# Altium schematic sheet OCB.SchDoc
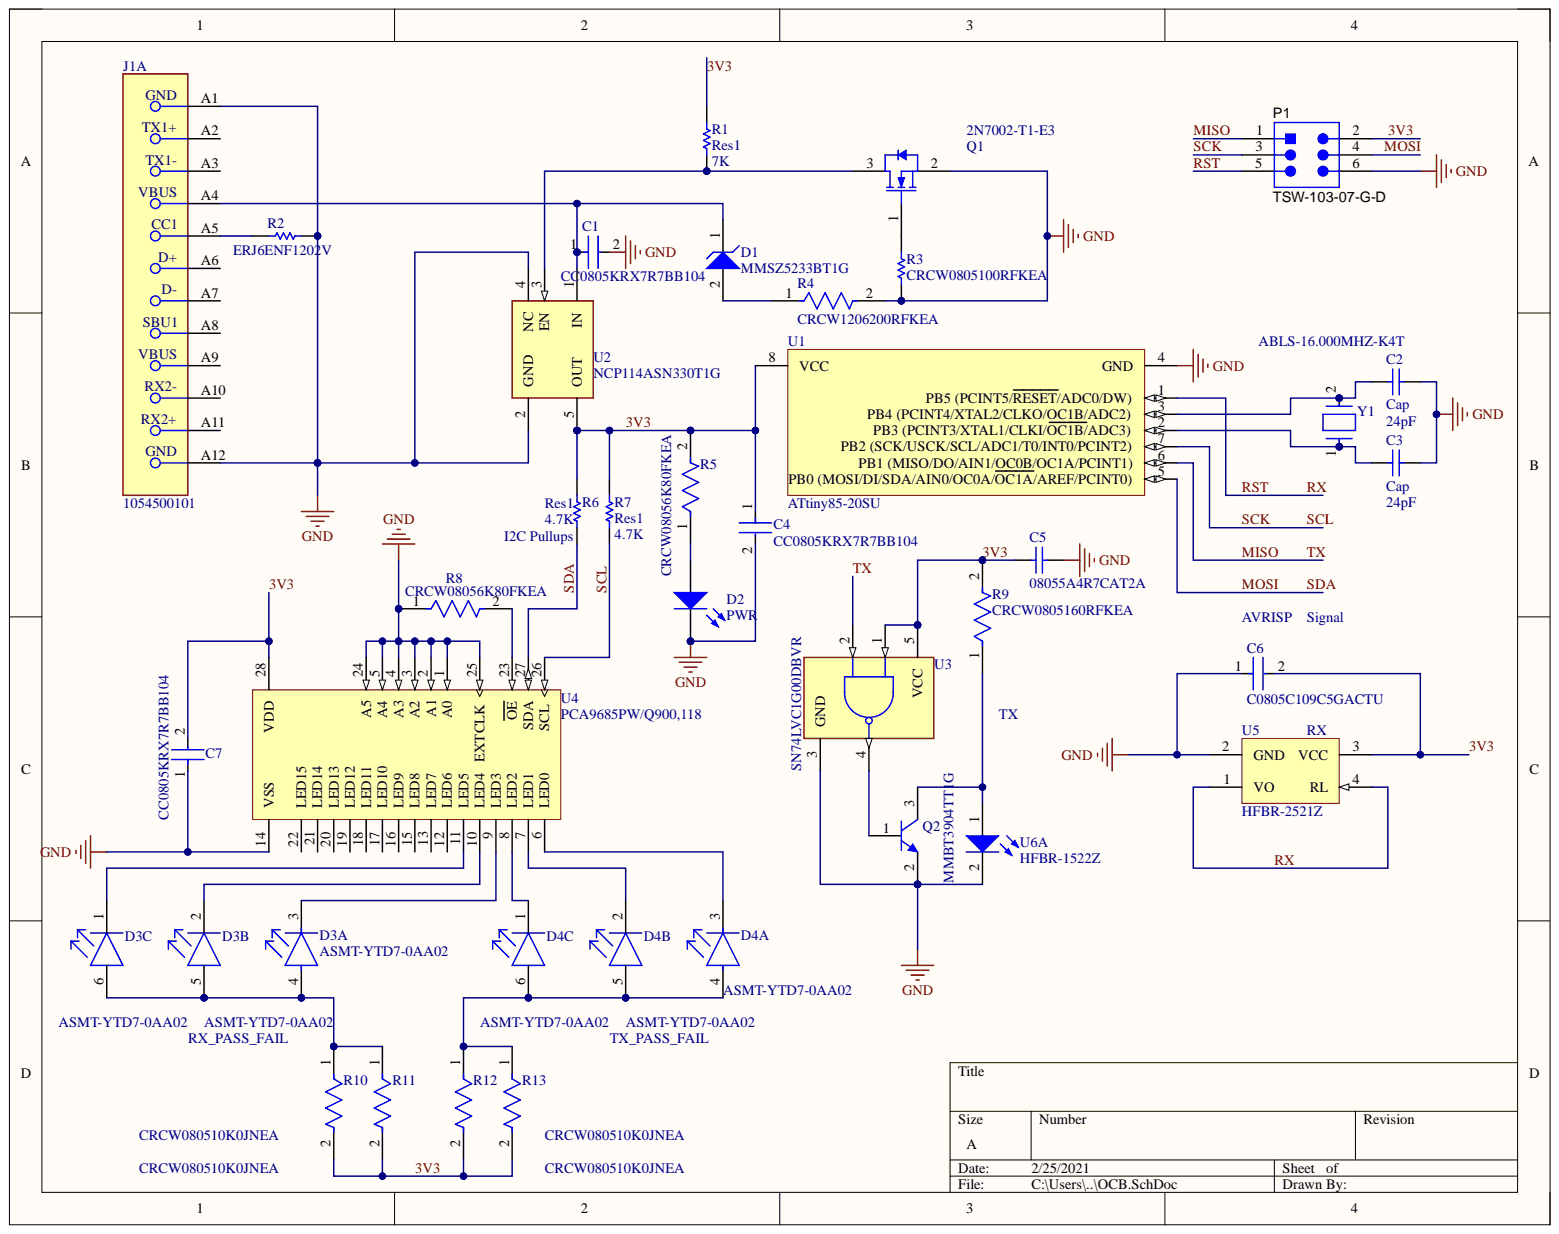
[diagram p0: OCB.SchDoc]

## Parts
C1  CC0805KRX7R7BB104  [FP-CC0805-0_85-MFG]
C2  Cap  value 24pF  [RAD-0.3]
C3  Cap  value 24pF  [RAD-0.3]
C4  CC0805KRX7R7BB104  [FP-CC0805-0_85-MFG]
C5  08055A4R7CAT2A  [CAPC2013X71X50ML20T25]
C6  C0805C109C5GACTU  [FP-C0805C-DN-MFG]
C7  CC0805KRX7R7BB104  [FP-CC0805-0_85-MFG]
D1  MMSZ5233BT1G  [ONSC-SOD-123-2-425-04_V]
D2  PWR  [LED-0]
D3  ASMT-YTD7-0AA02  [FP-PLCC-6-MFG]  (parts A,B,C)
D4  ASMT-YTD7-0AA02  [FP-PLCC-6-MFG]  (parts A,B,C)
J1  1054500101  [FP-1054500101-MFG]  (part A)
P1  TSW-103-07-G-D  [SMTC-TSW-103-07-G-D_V]
Q1  2N7002-T1-E3  [VISH-TO-236-3_A_L]
Q2  MMBT3904TT1G  [ONSC-SC-75-3-463-01_V]
R1  Res1  value 7K  [AXIAL-0.3]
R2  ERJ6ENF1202V  [RESC2013X70X40ML20T20]
R3  CRCW0805100RFKEA  [RESC2013X50X30LL20T20]
R4  CRCW1206200RFKEA  [FP-CRCW1206-e3-IPC_C]
R5  CRCW08056K80FKEA  [FP-CRCW0805-e3-IPC_A]
R6  Res1  value 4.7K  [AXIAL-0.3]
R7  Res1  value 4.7K  [AXIAL-0.3]
R8  CRCW08056K80FKEA  [FP-CRCW0805-e3-IPC_A]
R9  CRCW0805160RFKEA  [FP-CRCW0805-e3-IPC_C]
R10  CRCW080510K0JNEA  [FP-CRCW0805-e3-IPC_C]
R11  CRCW080510K0JNEA  [FP-CRCW0805-e3-IPC_C]
R12  CRCW080510K0JNEA  [FP-CRCW0805-e3-IPC_C]
R13  CRCW080510K0JNEA  [FP-CRCW0805-e3-IPC_C]
U1  ATtiny85-20SU  [8S2_M]
U2  NCP114ASN330T1G  [FP-483-MFG]
U3  SN74LVC1G00DBVR  [FP-DBV0005A-MFG]
U4  PCA9685PW/Q900,118  [SOT361-1_V]
U5  HFBR-2521Z  Type: RX  [BCM-HFBR-2521Z-4]
U6  HFBR-1522Z  [AVAG-HFBR-15X2Z_V]  (part A)
Y1  ABLS-16.000MHZ-K4T  [FP-ABLS-MFG]

## Nets
GND: C1.2 C2.1 C3.1 C4.2 C5.1 C6.1 C7.1 D2.2 J1.A1 J1.A12 P1.6 Q1.2 Q2.2 R2.2 R3.1 R4.2 R8.1 U1.4(GND) U2.2(GND) U2.4(NC) U3.3(GND) U4.1(A0) U4.2(A1) U4.3(A2) U4.4(A3) U4.5(A4) U4.14(VSS) U4.24(A5) U4.25(EXTCLK) U5.2(GND) U6.2
3V3: C4.1 C5.2 C6.2 C7.2 P1.2 R1.1 R5.2 R6.2 R7.2 R9.2 R10.2 R11.2 R12.2 R13.2 U1.8(VCC) U2.5(OUT) U3.1 U3.5(VCC) U4.28(VDD) U5.3(VCC)
MISO: P1.1 U1.6(PB1_(MISO/DO/AIN1/OC0B/OC1A/PCINT1)) U3.2
MOSI: P1.4 R6.1 U1.5(PB0_(MOSI/DI/SDA/AIN0/OC0A/OC1A/AREF/PCINT0)) U4.27(SDA)
RST: P1.5 U1.1(PB5_(PCINT5/RESET/ADC0/DW)) U5.1(VO) U5.4(RL)
SCK: P1.3 R7.1 U1.7(PB2_(SCK/USCK/SCL/ADC1/T0/INT0/PCINT2)) U4.26(SCL)
NetC1_1: C1.1 D1.1 J1.A4 U2.1(IN)
NetC2_2: C2.2 U1.3(PB4_(PCINT4/XTAL2/CLKO/OC1B/ADC2)) Y1.2
NetC3_2: C3.2 U1.2(PB3_(PCINT3/XTAL1/CLKI/OC1B/ADC3)) Y1.1
NetD1_2: D1.2 R4.1
NetD2_1: D2.1 R5.1
NetD3_1: D3.1 U4.11(LED5)
NetD3_2: D3.2 U4.10(LED4)
NetD3_3: D3.3 U4.9(LED3)
NetD3_4: D3.4 D3.5 D3.6 R10.1 R11.1
NetD4_1: D4.1 U4.8(LED2)
NetD4_2: D4.2 U4.7(LED1)
NetD4_3: D4.3 U4.6(LED0)
NetD4_4: D4.4 D4.5 D4.6 R12.1 R13.1
NetJ1_A5: J1.A5 R2.1
NetQ1_1: Q1.1 R3.2
NetQ1_3: Q1.3 R1.2 U2.3(EN)
NetQ2_1: Q2.1 U3.4
NetQ2_3: Q2.3 R9.1 U6.1
NetR8_2: R8.2 U4.23(OE)

Not connected: J1 A2 A3 A6 A7 A8 A9 A10 A11; U4 12(LED6) 13(LED7) 15(LED8) 16(LED9) 17(LED10) 18(LED11) 19(LED12) 20(LED13) 21(LED14) 22(LED15)

## Library data of the parts
C1 C4 C7 = CMP-1036-04402-6: CAP CER 0.1UF 16V X7R 0805; Manufacturer: Yageo; Capacitance: 100 nF; Material: Ceramic; Tolerance: 0.1; Value: 100 nF; Automotive: No; Category: Cap; Component Kind: Standard; ComponentLink2Description: Datasheet; ComponentLink2URL: http://www.yageo.com/documents/recent/UPY-GPHC_Y5V_6.3V-to-50V_8.pdf; Device Class L1: Passive Components; Device Class L2: Capacitors; Device Class L3: Ceramic Capacitors; Digikey Description: CAP CER 0.1UF 16V X7R 0805; Footprint Url: http://www.yageo.com/exep/pages/download/literatures/UPY-C_GEN_24.pdf; Height: 0.95mm; Ipc Land Pattern Name: CAPC200125X85; Lead Free: Yes; Library Name: Altium Content Vault; Manufacturer Part Number: CC0805KRX7R7BB104; Mouser Description: Multilayer Ceramic Capacitors MLCC - SMD/SMT 100nF 16V X7R 10%; Octopart: https://octopart.com/cc0805krx7r7bb104-yageo-39467769; Package: CC0805-0.85; RoHS: TRUE; Temperature: -30°C to +85°C; Temperature Characteristic: X7R; Temperature Range High: +85°C; Temperature Range Low: -30°C; Voltage Rating: 16 V
C2 = Cap: Capacitor; Value: 24pF; Component Kind: Standard; LatestRevisionDate: 17-Jul-2002; LatestRevisionNote: Re-released for DXP Platform.; Library Name: Miscellaneous Devices.IntLib; PackageDescription: Radial Cap, Thru-Hole; 2 Leads; 0.3 in Pin Spacing; PackageReference: RAD-0.3; Published: 8-Jun-2000; Signal Integrity: Cap; Simulation: CAP; Publisher: Altium Limited
C3 = Cap: Capacitor; Component Kind: Standard; LatestRevisionDate: 17-Jul-2002; LatestRevisionNote: Re-released for DXP Platform.; Library Name: Miscellaneous Devices.IntLib; PackageDescription: Radial Cap, Thru-Hole; 2 Leads; 0.3 in Pin Spacing; PackageReference: RAD-0.3; Published: 8-Jun-2000; Signal Integrity: Cap; Simulation: CAP; Publisher: Altium Limited
C5 = CMP-1036-00549-2: Manufacturer: AVX Interconnect / Elco; Component Kind: Standard; Library Name: Altium Content Vault; Part Number: 08055A4R7CAT2A
C6 = CMP-2007-00453-2: CAP CER 1PF 50V C0G/NP0 0805; Manufacturer: KEMET; Capacitance: 1.0 pF; Material: Ceramic; Temperature Characteristic: C0G; Temperature Coefficient: 30ppm/°C; Tolerance: 0.25 pF; Value: 1.0 pF; Automotive: No; Category: Cap; Component Kind: Standard; ComponentLink2Description: Datasheet; ComponentLink2URL: https://content.kemet.com/datasheets/KEM_C1003_C0G_SMD.pdf; Device Class L1: Passive Components; Device Class L2: Capacitors; Device Class L3: Ceramic Capacitors; Digikey Description: CAP CER 1PF 50V C0G/NP0 0805; Height: 0.88mm; Ipc Land Pattern Name: CAPC200125X78; Lead Free: Yes; Library Name: Altium Content Vault; Manufacturer Part Number: C0805C109C5GACTU; Mouser Description: Multilayer Ceramic Capacitors MLCC - SMD/SMT 50volts 1.0pF C0G 0.25%; Octopart: https://octopart.com/c0805c109c5gactu-kemet-130858; Package: C0805C-DN; RoHS: TRUE; Temperature: -55°C to +125°C; Temperature Range High: +125°C; Temperature Range Low: -55°C; Voltage Rating: 50 V
D1 = CMP-1060-00590-1: Zener Voltage Regulator, 500 mW, 2-Pin SOD-123, Pb-Free, Tape and Reel; Manufacturer: On Semiconductor; P Max (W): 0.5; VZ Typ (V): 6.0; Component Kind: Standard; ComponentLink1Description: Manufacturer URL; ComponentLink1URL: http://www.onsemi.com/; ComponentLink2Description: Datasheet; ComponentLink2URL: http://www.onsemi.com/pub_link/Collateral/MMSZ5221BT1-D.PDF; ComponentLink3Description: Package Specification; ComponentLink3URL: http://www.onsemi.com/pub_link/Collateral/425-04.PDF; DatasheetVersion: Rev. 12; Library Name: Altium Content Vault; Mounting Technology: Surface Mount; PackageDescription: 2-Pin SOD, Body 1.6 x 2.69 mm; PackageReference: SOD-123-2-425-04; PackageVersion: Rev. G, 10/2009; Packing: Tape and Reel; PartNumber: MMSZ5233BT1G; RoHS: Pb-Free
D2 = LED0: Typical INFRARED GaAs LED; Component Kind: Standard; LatestRevisionDate: 08-Jul-2005; LatestRevisionNote: Mech Layer 1 removed.; Library Name: Miscellaneous Devices.IntLib; PackageDescription: LED; 2 Leads; PackageReference: LED-0; Published: 8-Jun-2000; Simulation: LED0; Publisher: Altium Limited
D3 D4 = CMP-18399-000005-1: LED RGB DIFFUSED 6PLCC SMD; Manufacturer: Broadcom; Colour: Red, Green, Blue; Forward Current: 20mA; Forward Voltage: 2.1/3.1/3.1V; Led Orientation: Top View; Luminous Intensity: 650/1900/384mcd; Max Junction Temp: +110°C; Peak Emmision Wavelength: 630/522/465nm; Power Dissipation: 125/90/90mW; Reverse Voltage: 4V; Viewing Angle: 120°; Wavelength: 623/529/469nm; Automotive: No; Category: Diode; Component Kind: Standard; ComponentLink2Description: Datasheet; ComponentLink2URL: https://docs.broadcom.com/doc/AV02-3873EN; Device Class L1: Optoelectronics; Device Class L2: LEDs; Device Class L3: unset; Digikey Description: LED RGB DIFFUSED 6PLCC SMD; Height: 2mm; Lead Free: Yes; Library Name: Altium Content Vault; Manufacturer Part Number: ASMT-YTD7-0AA02; Mouser Description: Standard LEDs - SMD PLCC6,T/MT SILICONE RGB; Octopart: https://octopart.com/asmt-ytd7-0aa02-broadcom-71127359; Package: PLCC-6; RoHS: TRUE; Temperature: -40°C to +100°C; Temperature Range High: +100°C; Temperature Range Low: -40°C
J1 = CMP-04767-000004-1: CONN RCP USB3.1 TYPEC 24P SMD RA; Manufacturer: Molex; Conn Orientation: Right Angle; Current Rating: 0.25/1.25/5A; Is Female: yes; Number Of Contacts: 24; Pitch: 0.5mm; Shielding: true; Voltage Rating: 30V; Automotive: No; Category: Conn; Component Kind: Standard; ComponentLink2Description: Datasheet; ComponentLink2URL: https://www.molex.com/webdocs/datasheets/pdf/en-us/1054500101_IO_CONNECTORS.pdf; Contact Material: Gold,Nickel; Device Class L1: Connectors; Device Class L2: USB Connectors; Device Class L3: unset; Digikey Description: CONN RCP USB3.1 TYPEC 24P SMD RA; Footprint Url: https://www.molex.com/pdm_docs/sd/1054500101_sd.pdf; Height: 3.44mm; Lead Free: Yes; Library Name: Altium Content Vault; Manufacturer Part Number: 1054500101; Mouser Description: USB Shielded I/O Receptacle; Type C; Right Angle; Surface Mount; Gold over Nickel; Octopart: https://octopart.com/105450-0101-molex-68082325; Package: 0; RoHS: TRUE; Temperature: -30°C to +85°C; Temperature Range High: +85°C; Temperature Range Low: -30°C; Usb Standard: USB 3.1
P1 = CMP-2000-07329-1: Through Hole Header, Pitch 2.54 mm, 2 x 3 Position, Height 8.58 mm, Tail Length 2.54 mm, -55 to 125 degC, RoHS, Bulk; Manufacturer: Samtec-Inc.; Connector Type: Header,Unshrouded; Number of Positions: 6; Number of Positions Loaded: All; Pitch: 0.100"(2.54mm); Category: Connectors,Interconnects; Color: Black; Component Kind: Standard; Contact Finish: Gold; Contact Finish Thickness: 10µin(0.25µm); Contact Mating Length: 0.230"(5.84mm); Contact Type: MalePin; Datasheet URL: http://suddendocs.samtec.com/catalog_english/tsw_th.pdf; Datasheet Version: 12/2015; Family: RectangularConnectors-Headers,MalePins; Fastening Type: -; Features: -; Library Name: Altium Content Vault; Manufacturer URL: http://www.samtec.com/; Mounting Technology: ThroughHole; Mounting Type: ThroughHole; Number of Rows: 2; Package Description: Through Hole Header, Pitch 2.54 mm, 2 x 3 Position, Height 8.58 mm, Tail Length 2.54 mm; Package Reference: TSW-103-07-G-D; Package URL: http://suddendocs.samtec.com/prints/tsw-xxx-xx-xxx-x-xx-xxx-mkt.pdf; Packaging: Bulk; Row Spacing: 0.100"(2.54mm); Series: TSW; Termination: Solder
Q1 = CMP-2000-05565-1: N-Channel MOSFET, 115 mA, 60 V, -55 to 150 degC, 3-Pin SOT23, RoHS, Tape and Reel; Manufacturer: Vishay; Continuous Drain Current Id: 115mA; Drain Source Voltage Vds: 60V; No. of Pins: 3; On Resistance Rds(on): 7.5ohm; Power Dissipation Pd: 200mW; Rds(on) Test Voltage Vgs: 10V; SVHC: NoSVHC(17-Dec-2014); Threshold Voltage Vgs: 2.1V; Transistor Case Style: TO-236; Transistor Polarity: NChannel; Component Kind: Standard; Datasheet URL: http://www.vishay.com/docs/70226/70226.pdf; Datasheet Version: Rev. F, 07/2001; Library Name: Altium Content Vault; Manufacturer URL: http://www.vishay.com/; Mounting Technology: SurfaceMount; MSL: MSL1-Unlimited; Operating Temperature Max: 150°C; Package Description: 3-Pin Small Outline Transistor, Body 2.92 x 1.3 mm, Pitch 0.95 mm; Package Reference: TO-236-3; Package URL: http://www.vishay.com/docs/71196/71196.pdf
Q2 = CMP-1048-00420-1: General Purpose Transistor, NPN Silicon, 3-Pin SC-75, Pb-Free, Tape and Reel; Manufacturer: On Semiconductor; fT Min (MHz): 300; IC Continuous (A): 0.2; Component Kind: Standard; ComponentLink1Description: Manufacturer URL; ComponentLink1URL: http://www.onsemi.com/; ComponentLink2Description: Datasheet; ComponentLink2URL: http://www.onsemi.cn/pub_link/Collateral/MMBT3904TT1-D.PDF; ComponentLink3Description: Package Specification; ComponentLink3URL: http://www.onsemi.com/pub_link/Collateral/463-01.PDF; DatasheetVersion: Rev. 5; Library Name: Altium Content Vault; Mounting Technology: Surface Mount; PackageDescription: 3-Pin Small Outline Transistor, Body 1.6 x 0.8 mm, Pitch 0.5 mm; PackageReference: SC-75-3-463-01; PackageVersion: Rev. F, 07/2005; Packing: Tape and Reel; PartNumber: MMBT3904TT1G; Polarity: NPN; RoHS: TRUE
R1 R6 R7 = Res1: Resistor; Component Kind: Standard; LatestRevisionDate: 17-Jul-2002; LatestRevisionNote: Re-released for DXP Platform.; Library Name: Miscellaneous Devices.IntLib; PackageDescription: Axial Device, Thru-Hole; 2 Leads; 0.3 in Pin Spacing; PackageReference: AXIAL-0.3; Published: 8-Jun-2000; Signal Integrity: Res; Simulation: RESISTOR; Publisher: Altium Limited
R2 = CMP-2001-00513-1: Manufacturer: Panasonic; Component Kind: Standard; Library Name: Altium Content Vault; Part Number: ERJ6ENF1202V
R3 = CMP-2001-04901-1: Manufacturer: Vishay Dale; Component Kind: Standard; Library Name: Altium Content Vault; Part Number: CRCW0805100RFKEA
R4 = CMP-2003-01935-2: RES Thick Film, 200Ω, 1%, 0.25W, 100ppm/°C, 1206; Max Power Dissipation: 250mW; Resistance: 200R; Voltage Rating: 200V; Case Code (Imperial): 1206; Case Code (Metric): 3216; Case/Package: 1206; Component Kind: Standard; Composition: Thick Film; Contact Plating: Tin; Depth: 1.6mm; Features: Automotive AEC-Q200; Height: 0.55mm; Lead Free: Lead Free; Length: 3.2mm; Library Name: Altium Content Vault; Max Operating Temperature: 155°C; Military Standard: Not; Min Operating Temperature: -55°C; Number of Pins: 2; Number of Terminations: 2; Package Quantity: 10; Packaging: Tape and Reel; Power Rating: 250mW; Radiation Hardening: No; Temperature Coefficient: 100ppm/°C; Termination: SMD/SMT; Tolerance: 1%; Voltage Rating (DC): 200V; Weight: 0.000353oz; Width: 1.6mm; Working Voltage: 200V
R5 R8 = CMP-2001-04088-2: RES Thick Film, 6.8kΩ, 1%, 0.125W, 100ppm/°C, 0805; Max Power Dissipation: 125mW; Resistance: 6.8kR; Voltage Rating: 150V; Case Code (Imperial): 0805; Case Code (Metric): 2012; Case/Package: 0805; Component Kind: Standard; Composition: Thick Film; Contact Plating: Tin; Depth: 1.25mm; Features: Automotive AEC-Q200; Height: 0.45mm; Lead Free: Lead Free; Length: 2mm; Library Name: Altium Content Vault; Max Operating Temperature: 155°C; Military Standard: Not; Min Operating Temperature: -55°C; Mount: Surface Mount; Number of Terminations: 2; Package Quantity: 10; Packaging: Tape and Reel; Power Rating: 125mW; Radiation Hardening: No; Temperature Coefficient: 100ppm/°C; Termination: SMD/SMT; Tolerance: 1%; Voltage Rating (DC): 150V; Weight: 0.000194oz; Width: 0.049inch
R9 = CMP-1013-00418-2: RES Thick Film, 160Ω, 1%, 0.125W, 100ppm/°C, 0805; Max Power Dissipation: 125mW; Resistance: 160R; Voltage Rating: 150V; Case Code (Imperial): 0805; Case Code (Metric): 2012; Case/Package: 0805; Component Kind: Standard; Composition: Thick Film; Depth: 1.25mm; Features: Automotive AEC-Q200; Height: 0.02inch; Lead Free: Lead Free; Length: 2mm; Library Name: Altium Content Vault; Max Operating Temperature: 155°C; Military Standard: Not; Min Operating Temperature: -55°C; Number of Pins: 2; Number of Terminations: 2; Package Quantity: 10; Packaging: Tape and Reel; Power Rating: 125mW; Temperature Coefficient: 100ppm/°C; Termination: SMD/SMT; Tolerance: 1%; Voltage Rating (DC): 150V; Weight: 0.000194oz; Width: 0.049inch
R10-R13 = CMP-2001-04910-2: RES Thick Film, 10kΩ, 5%, 0.125W, 200ppm/°C, 0805; Power Rating: 125mW; Resistance: 10kR; Voltage Rating: 150V; Case Code (Imperial): 0805; Case Code (Metric): 2012; Case/Package: 0805; Component Kind: Standard; Composition: Thick Film; Contact Plating: Tin; Depth: 1.25mm; Height: 0.45mm; Lead Free: Lead Free; Length: 2mm; Library Name: Altium Content Vault; Max Operating Temperature: 155°C; Military Standard: Not; Min Operating Temperature: -55°C; Mount: Surface Mount; Package Quantity: 5000; Packaging: Tape and Reel; Radiation Hardening: No; Temperature Coefficient: 200ppm/°C; Termination: SMD/SMT; Tolerance: 5%; Voltage Rating (DC): 150V; Weight: 0.000194oz; Width: 1.25mm
U1 = CMP-0093-00173-2: 8-bit AVR Microcontroller, 20MHz, 2.7-5.5V, 8K Flash, 512 Bytes EEPROM, 512 Bytes SRAM, Industrial Grade (-40°C to 85°C), 8-Lead SOIC, Pb-Free; Component Kind: Standard; ComponentLink1Description: Manufacturer URL; ComponentLink1URL: http://www.atmel.com/; ComponentLink2Description: ATtiny25/45/85 Datasheet; ComponentLink2URL: http://www.atmel.com/dyn/resources/prod_documents/doc2586.pdf; ComponentLink3Description: ATtiny25/45/85 Summary; ComponentLink3URL: http://www.atmel.com/dyn/resources/prod_documents/2586S.pdf; DatasheetVersion: revN, Apr-2011; Library Name: Altium Content Vault; PackageDescription: 8-Lead, 0.208" Body, Plastic Small Outline Package (EIAJ); PackageReference: 8S2; PackageVersion: revF, Apr-2008; RevisionNote: DatasheetVersion updated. URLs added.
U2 = CMP-07128-000001-1: IC REG LINEAR 3.3V 300MA 5TSOP; Manufacturer: ON Semiconductor; Accuracy Percentage: 1%; Dropout Voltage: 150mV; Max Junction Temp: +150°C; Max Supply Voltage: 5.5V; Min Supply Voltage: 1.7V; Nominal Supply Current: 50uA; Number Of Outputs: 1; Output Type: Fixed; Standoff Height: 0.01mm; Automotive: No; Category: IC; Component Kind: Standard; ComponentLink2Description: Datasheet; ComponentLink2URL: https://www.onsemi.com/pub/Collateral/NCP114-D.PDF; Device Class L1: Integrated Circuits (ICs); Device Class L2: Power Management ICs; Device Class L3: Voltage Regulators - Linear; Digikey Description: IC REG LINEAR 3.3V 300MA 5TSOP; Footprint Url: https://www.onsemi.com/pub/Collateral/483.PDF; Height: 1.1mm; Lead Free: Yes; Library Name: Altium Content Vault; Manufacturer Part Number: NCP114ASN330T1G; Octopart: https://octopart.com/ncp114asn330t1g-on+semiconductor-74628742; Output Current: 300mA; Output Voltage: 3.3V; Package: 483; RoHS: TRUE; Temperature: to +150°C; Temperature Range High: +150°C
U3 = CMP-0859-00113-4: IC GATE NAND 1CH 2-INP SOT23-5; Manufacturer: Texas Instruments; Frequency: 100MHz; Logic Function Desc: Inverting; Max Supply Voltage: 5.5V; Min Supply Voltage: 1.65V; Nominal Supply Current: 10uA; Number Of Circuits: 1; Number Of Inputs: 2; Propagation Delay: 4.3ns; Standoff Height: 0mm; Ambient Temperature Range High: +125°C; Ambient Temperature Range Low: -40°C; Automotive: No; Category: IC; Component Kind: Standard; ComponentLink2Description: Datasheet; ComponentLink2URL: http://www.ti.com/lit/ds/symlink/sn74lvc1g00.pdf; Device Class L1: Integrated Circuits (ICs); Device Class L2: Logic ICs; Device Class L3: Logic Gates; Digikey Description: IC GATE NAND 1CH 2-INP SOT23-5; Height: 1.45mm; Ipc Land Pattern Name: SOT95P280X145-5; Lead Free: Yes; Library Name: Altium Content Vault; Manufacturer Part Number: SN74LVC1G00DBVR; Mouser Description: IC Mos 74LVC1G00/SO/SN74LVC1G00DBVR TEXAS INSTRUMENT RoHS LVC SOt23; Number Of Outputs: 1; Octopart: https://octopart.com/sn74lvc1g00dbvr-texas+instruments-465560; Package: DBV0005A; RoHS: TRUE; Temperature: -40°C to +125°C; Temperature Range High: +125°C; Temperature Range Low: -40°C
U4 = CMP-1595-00002-2: 16-Channel, 12-Bit PWM Fm+ I2C-Bus LED Controller, 2.3 to 5.5 V, -40 to 85 degC, 28-Pin TSSOP (SOT361-1), RoHS, Tape and Reel; Frequency: 25000000 Hz; Supply Current Max: 10 mA; Supply Voltage Max: 5.5 V; Supply Voltage Min: 2.3 V; Code_JEDEC: MO-153; Component Kind: Standard; ComponentLink1Description: Manufacturer URL; ComponentLink1URL: http://www.nxp.com/; ComponentLink2Description: Datasheet; ComponentLink2URL: http://www.nxp.com/documents/data_sheet/PCA9685.pdf; ComponentLink3Description: Package Specification; ComponentLink3URL: http://www.nxp.com/documents/outline_drawing/sot361-1_po.pdf; DatasheetVersion: Rev. 4; Library Name: Altium Content Vault; Mounting Technology: Surface Mount; PackageDescription: 28-Pin Small Outline Package, Body 9.7 x 4.4 mm, Pitch 0.65 mm; PackageVersion: 02/2003; Packing: Tape and Reel; PartNumber: PCA9685; RoHS: TRUE; Temperature Max: 85 degC; Temperature Min: -40 degC
U5 = CMP-2000-05068-1: The Versatile Fiber Optic Connection, 5 MBd, -40 to 85 degC, 4-Pin, RoHS, Rail/Tube; Manufacturer: Broadcom; Connector Type: Round; Maximum Data Rate: 5MBd; Maximum Operating Supply Voltage: 7V; Maximum Output Current: 25mA; Maximum Power Dissipation: 40mW; Minimum Operating Supply Voltage: -0.5V; Peak Wavelength: 600nm; Pin_Count: 6; Product Height: 7.6mm; Product Length: 18.8mm; Product Width: 12.2mm; Typical Operating Supply Voltage: 1.8V; Component Kind: Standard; Datasheet URL: http://docs.avagotech.com/docs/AV02-1501EN; Datasheet Version: Sep-14; Family: HFBR-2521; Library Name: Altium Content Vault; Manufacturer URL: http://www.broadcom.com/; Maximum Operating Temperature: 85C; Minimum Operating Temperature: -40C; Mounting: ThroughHole; Package URL: http://docs.avagotech.com/docs/AV02-1501EN; Product Type: OpticalFiber
U6 = CMP-2000-06401-1: The Versatile Fiber Optic Connection, 5 V, -40 to 85 degC, 6-Pin THD, RoHS, Tube; Manufacturer: Avago; Capacitance: 86pF; Connector Type: VersatileLink; Current - DC Forward (If) (Max): 80mA; Online Catalog: HFBR-15xxZ; Other Names: 516-2044HFBR1522Z; PCN Design/Specification: Mold/Design29/Jul/2011Lead-FrameUpdates09/Jun/2015Lead-FrameUpdate09/Jun/2015; Voltage - DC Reverse (Vr) (Max): 5V; Voltage - Forward (Vf) (Typ): 2.02V; Wavelength: 660nm; Category: Optoelectronics; Component Kind: Standard; Datasheet URL: http://www.avagotech.com/docs/AV02-1501EN; Datasheet Version: 09/2014; Family: FiberOptics-Transmitters-Discrete; Library Name: Altium Content Vault; Manufacturer URL: http://www.avagotech.com/; Mounting Technology: ThroughHole; Package Description: 6-Pin Through Hole Device, Body 18.8 x 12.19 mm, Pitch 2.54 mm; Package Reference: HFBR-15X2Z; Series: -; Spectral Bandwidth: -; Standard Package: 200
Y1 = CMP-27762-000003-1: CRYSTAL 16.0000MHZ 18PF SMD; Manufacturer: Abracon; Esr: 40Ω; Frequency: 16MHz; Stability: 50ppm; Standoff Height: 0mm; Tolerance: 30ppm; Automotive: No; Category: Crys; Component Kind: Standard; ComponentLink2Description: Datasheet; ComponentLink2URL: https://abracon.com/Resonators/abls.pdf; Device Class L1: Passive Components; Device Class L2: Crystals / Oscillators; Device Class L3: Crystals; Digikey Description: CRYSTAL 16.0000MHZ 18PF SMD; Height: 4.3mm; Lead Free: Yes; Library Name: Altium Content Vault; Load Capacitance: 18pF; Manufacturer Part Number: ABLS-16.000MHZ-K4T; Mouser Description: Crystal 16MHz ±30ppm (Tol) ±50ppm (Stability) 18pF FUND 40Ohm 2-Pin HC-49/US SMD T/R; Octopart: https://octopart.com/abls-16.000mhz-k4t-abracon-18618626; Package: ABLS; RoHS: TRUE; Series: ABLS; Temperature: -40°C to +125°C; Temperature Range High: +125°C; Temperature Range Low: -40°C
